# Altium schematic sheet Sheet1.SchDoc(Sheet1)
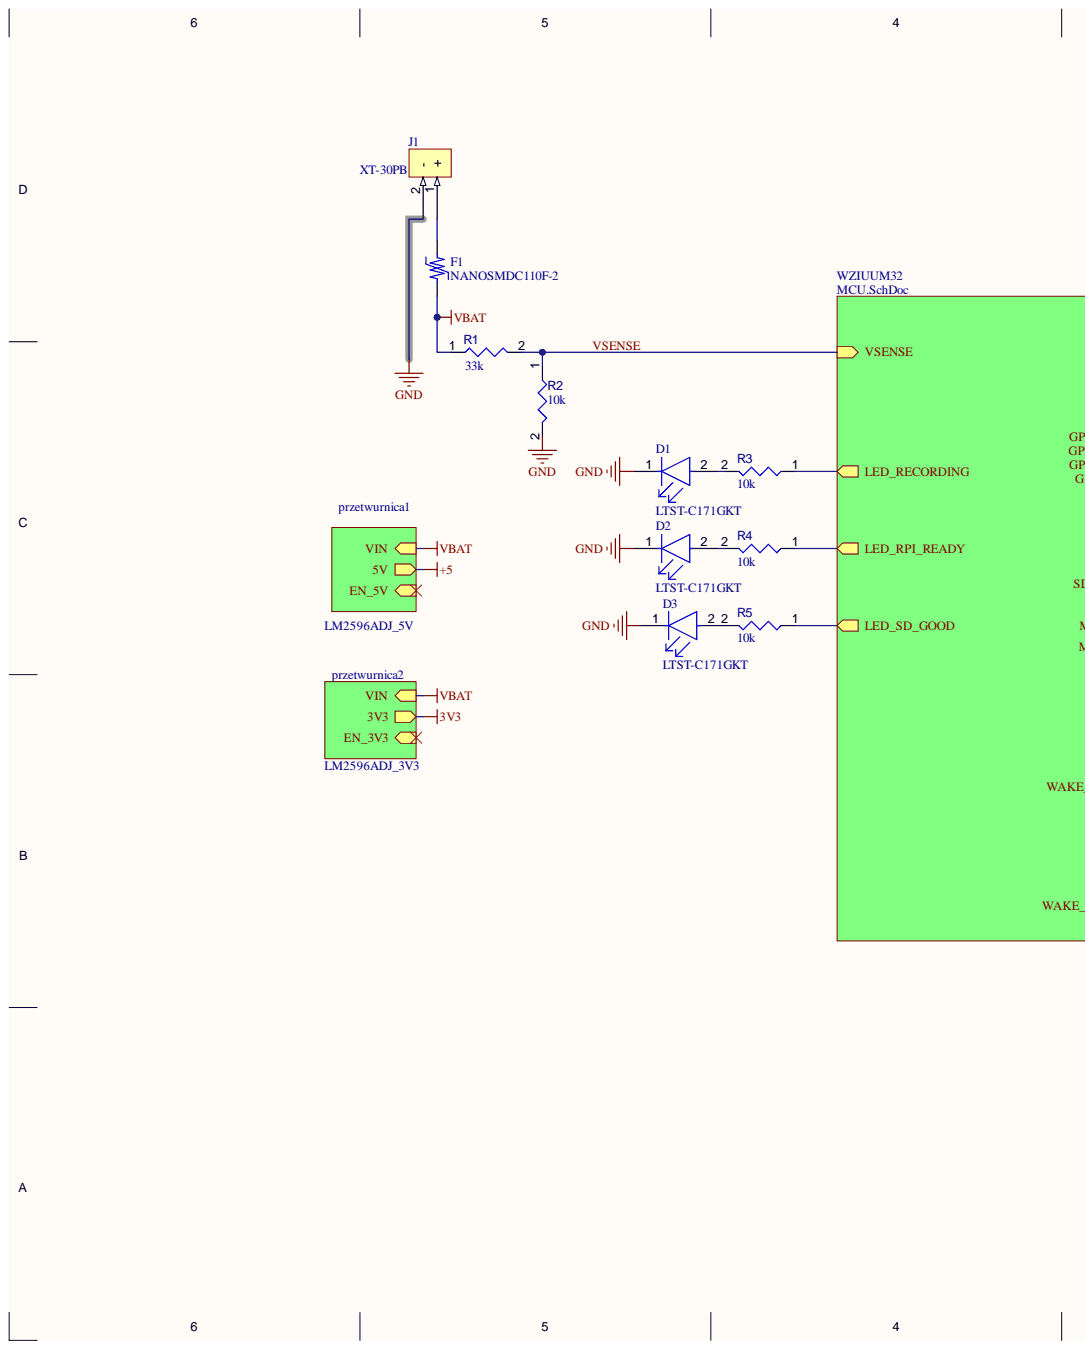
[diagram p0: Sheet1.SchDoc, left]
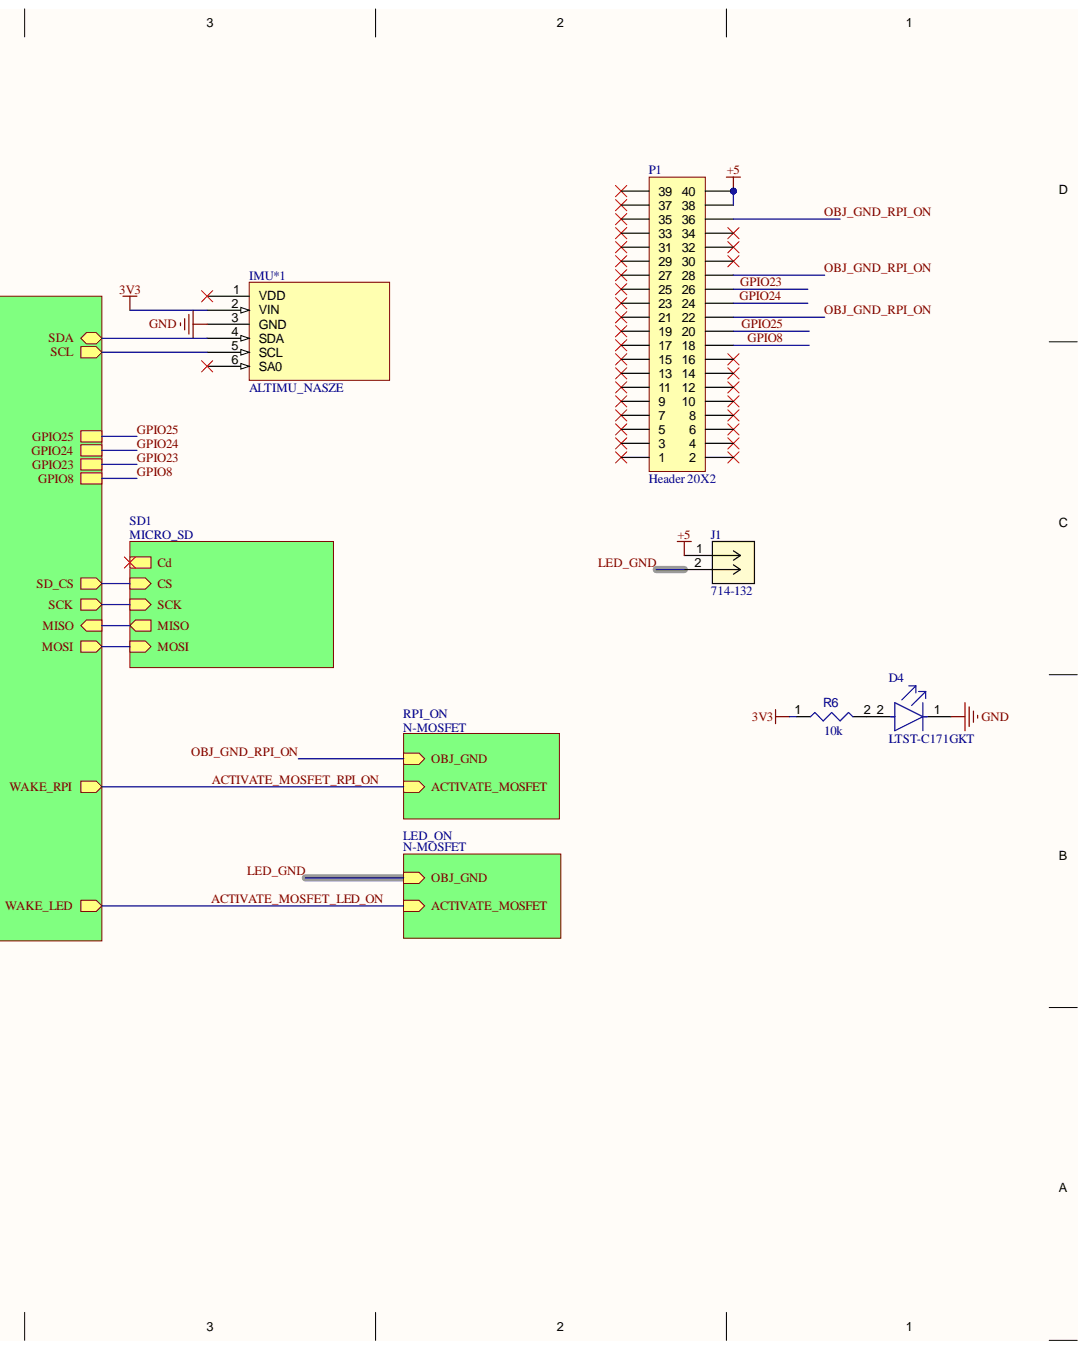
[diagram p1: Sheet1.SchDoc, right]

## Parts
D1  LTST-C171GKT  [FP-LTST-C171GKT-MFG]
D2  LTST-C171GKT  [FP-LTST-C171GKT-MFG]
D3  LTST-C171GKT  [FP-LTST-C171GKT-MFG]
D4  LTST-C171GKT  [FP-LTST-C171GKT-MFG]
F1  NANOSMDC110F-2  [TECO-NANOSMDC110F-2_V]
IMU*1  ALTIMU_NASZE  [New footprint 1]
J1  XT-30PB  [New footprint 1]
J1  714-132  [CONN_2_714-132_WAG]
P1  Header 20X2  [HDR2X20]
R1  value 33k  [RESC2013X50X35ML15T15]  (hidden comment: Resistor 33k +/-1% 0805 125 mW)
R2  value 10k  [RESC2013X50X35ML15T15]  (hidden comment: Resistor 10k +/-1% 0805 125 mW)
R3  value 10k  [RESC2013X50X35ML15T15]  (hidden comment: Resistor 10k +/-1% 0805 125 mW)
R4  value 10k  [RESC2013X50X35ML15T15]  (hidden comment: Resistor 10k +/-1% 0805 125 mW)
R5  value 10k  [RESC2013X50X35ML15T15]  (hidden comment: Resistor 10k +/-1% 0805 125 mW)
R6  value 10k  [RESC2013X50X35ML15T15]  (hidden comment: Resistor 10k +/-1% 0805 125 mW)

## Nets
3V3: IMU*1.2(VIN) R6.1
+5: J1.1 P1.38 P1.40
GND: D1.1 D2.1 D3.1 D4.1 IMU*1.3(GND) J1.2 R2.2
VBAT: F1.2 R1.1
LED_GND: J1.2
RPI_GND: P1.22 P1.28 P1.36
RPI_GPIO8: P1.18
RPI_GPIO23: P1.26
RPI_GPIO24: P1.24
RPI_GPIO25: P1.20
VSENSE: R1.2 R2.1
NetD1_2: D1.2 R3.2
NetD2_2: D2.2 R4.2
NetD3_2: D3.2 R5.2
NetD4_2: D4.2 R6.2
NetF1_1: F1.1 J1.1
NetIMU*1_1: IMU*1.1(VDD)
NetIMU*1_4: IMU*1.4(SDA)
NetIMU*1_5: IMU*1.5(SCL)
NetIMU*1_6: IMU*1.6(SA0)
NetP1_1: P1.1
NetP1_2: P1.2
NetP1_3: P1.3
NetP1_4: P1.4
NetP1_5: P1.5
NetP1_6: P1.6
NetP1_7: P1.7
NetP1_8: P1.8
NetP1_9: P1.9
NetP1_10: P1.10
NetP1_11: P1.11
NetP1_12: P1.12
NetP1_13: P1.13
NetP1_14: P1.14
NetP1_15: P1.15
NetP1_16: P1.16
NetP1_17: P1.17
NetP1_19: P1.19
NetP1_21: P1.21
NetP1_23: P1.23
NetP1_25: P1.25
NetP1_27: P1.27
NetP1_29: P1.29
NetP1_30: P1.30
NetP1_31: P1.31
NetP1_32: P1.32
NetP1_33: P1.33
NetP1_34: P1.34
NetP1_35: P1.35
NetP1_37: P1.37
NetP1_39: P1.39
NetR3_1: R3.1
NetR4_1: R4.1
NetR5_1: R5.1
Cd:
CS:
EN_3V3:
EN_5V:
MISO:
MOSI:
SCK:
WAKE_LED:
WAKE_RPI:

## Library data of the parts
D1-D4 = CMP-22017-000007-1: LED GREEN CLEAR CHIP SMD; Dominant Wavelength: 569nm; Forward Current: 30mA; Forward Voltage: 2.1V; Lens Color: Colorless; Lens Style: Diffused; Luminous Intensity: 12mcd; Number of Elements: 1; Power Dissipation: 100mW; Reverse Voltage: 5V; Test Current: 10mA; Viewing Angle: 130°; Wavelength: 585nm; Case Code (Imperial): 0805; Case Code (Metric): 2012; Case/Package: 0805; Color: Green; Component Kind: Standard; Depth: 1.25mm; Height: 0.8mm; Illumination Color: Green; Lead Free: Lead Free; Length: 2mm; Lens Transparency: Clear; Library Name: Altium Content Vault; Max Operating Temperature: 85°C; Min Operating Temperature: -55°C; Mount: Surface Mount; Number of LEDs: 1; Number of Pins: 2; Package Quantity: 1; Packaging: Tape and Reel; Power Rating: 100mW; Radiation Hardening: No; Width: 1.25mm
F1 = CMP-2000-06805-1: PolySwitch Resettable Device, 6 V, 1.1 A, -40 to 85 degC, 2-Pin SMD, RoHS, Tape and Reel; Manufacturer: TEConnectivity/CircuitProtection; Agency Approvals: CSA,TUV,UL; Hold Current: 1.1A; Lead Diameter: N/R; Maximum Current Rating: 100A; Maximum Post Trip Resistance: 0.2Ohm; Maximum Trip Time: 0.1@8As; Maximum Voltage Rating: 6VDC; Minimum Initial Resistance: 0.07Ohm; Trip Current: 2.2A; Typical Power Dissipation: 0.8(Max)W; Applications: N/A; Component Kind: Standard; Datasheet URL: http://www.te.com/commerce/DocumentDelivery/DDEController?Action=showdoc&DocId=Catalog+Section%7FCLP00010%7FA%7Fpdf%7FEnglish%7FENG_CS_CLP00010_A_CLP00010.pdf%7FRF1359-000; Datasheet Version: 11/2013; Dimension: 3.4(Max)x1.8(Max)x0.67(Max)mm; Family: nanoSMD; Library Name: Altium Content Vault; Manufacturer URL: http://www.te.com/; Maximum Initial Resistance: N/A; Mounting: SurfaceMount; Mounting Technology: SurfaceMount; Number of Terminals: 2; Operating Temperature: 85(Max)C; Package Description: 2-Pin Surface Mount Device, Body 3.2 x 1.585 mm; Package Reference: NANOSMDC110F-2; Package/Case: 1206; Packaging: TapeandReel; Part No: NANOSMDC110F-2; RoHS: Yes; Seated Plane Height: N/R; Terminal Pitch: N/A; Wire Form: N/R
IMU*1 = CMP-001-0000-3: Component Kind: Standard; Library Name: Gayload team
J1 = CMP-002-00072-2: ugabuga; Component Kind: Standard; Library Name: Gayload team
J1 = 714-132: No Description Available; Mfr_Name: Wago; Component Kind: Standard; Library Name: 714-132.IntLib; Manufacturer_Part_Number: 714-132; RefDes: RefDes; Type: DEV; Copyright: Copyright (C) 2022 Ultra Librarian. All rights reserved.
P1 = Header 20X2: Header, 20-Pin, Dual row; Component Kind: Standard; LatestRevisionDate: 17-Jul-2002; LatestRevisionNote: Re-released for DXP Platform.; Library Name: Miscellaneous Connectors.IntLib; Signal Integrity: Connector; Publisher: Altium Limited
R1-R6 = CMP-009-00018-5: Chip Resistor, 10 KOhm, +/- 1%, 125 mW, -55 to 155 degC, 0805 (2012 Metric); Power: 125mW; Voltage Rating: 150V; Case/Package: 0805; Component Kind: Standard; Max Operating Temperature: 155°C; Min Operating Temperature: -55°C; Mounting Technology: SM; Pins: 2; RoHS Compliant: True; Tolerance: 1%; Library Name: Gayload team
